# Altium schematic sheet ESP32-HWE-Dev-Power.SchDoc(ESP32-HWE-Dev-Power)
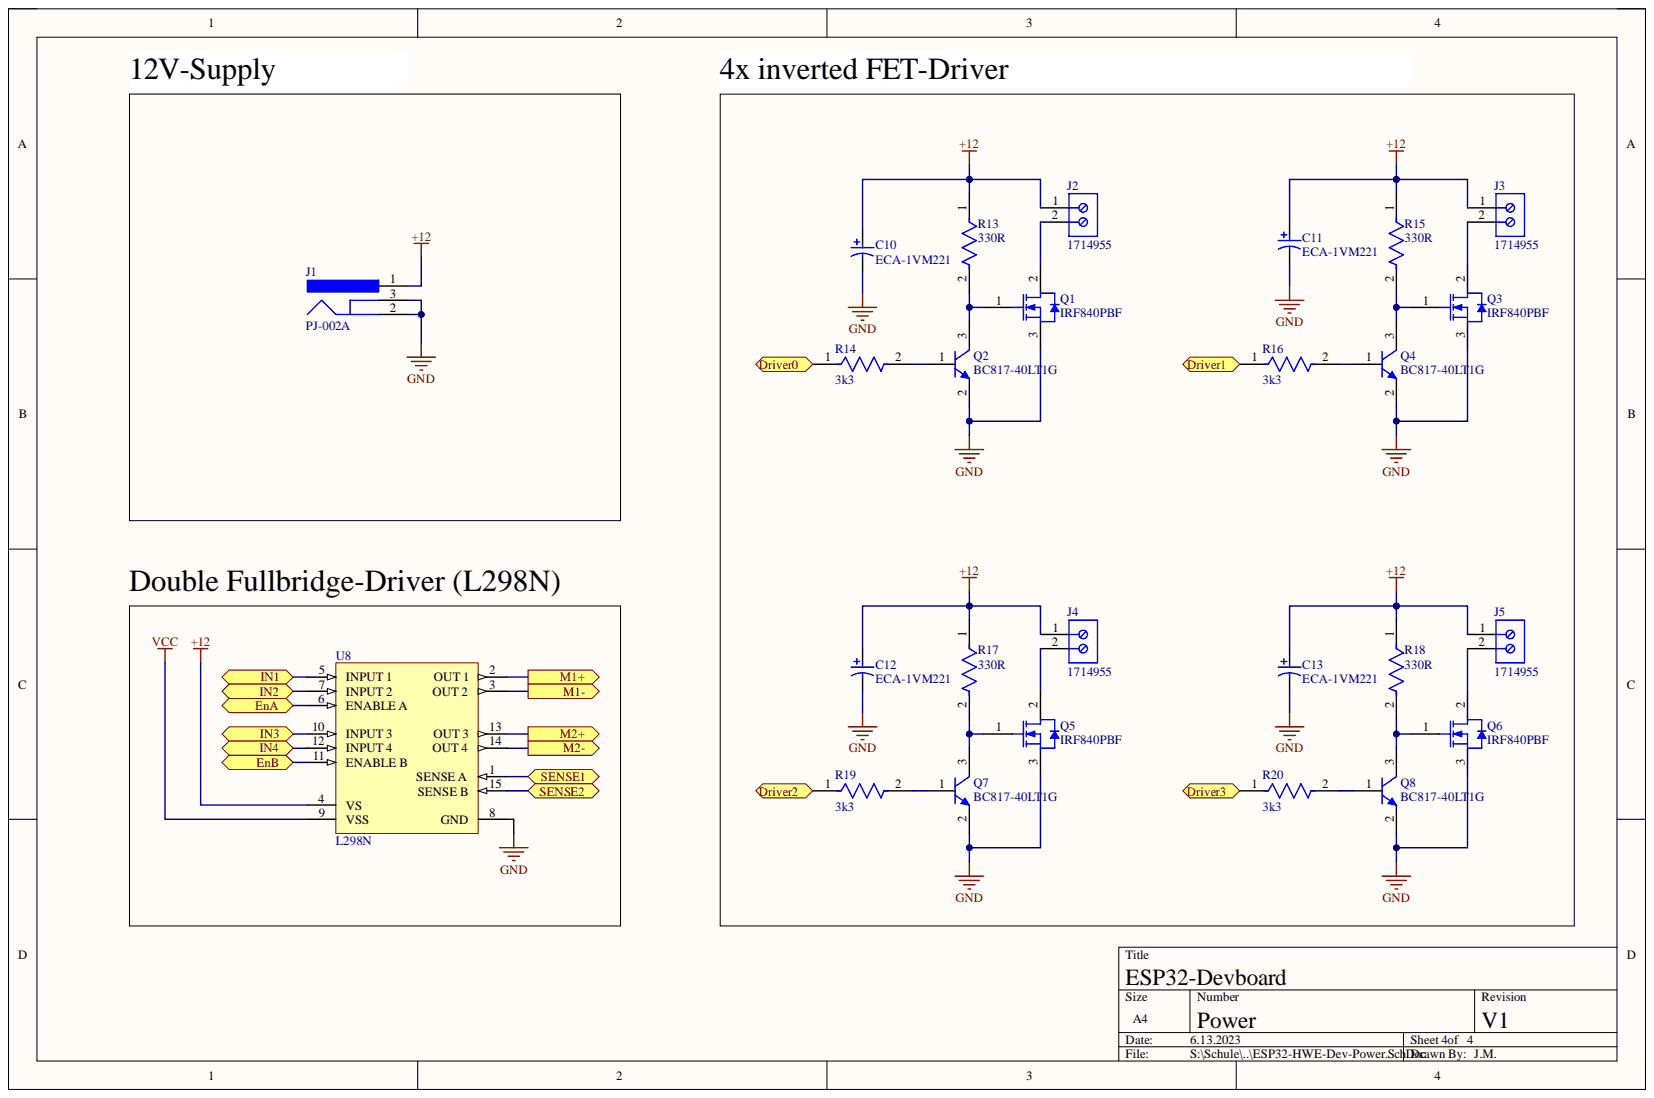
[diagram p0: ESP32-HWE-Dev-Power.SchDoc]

## Parts
C10  ECA-1VM221  [PNSC-ECA-1VM221-2]
C11  ECA-1VM221  [PNSC-ECA-1VM221-2]
C12  ECA-1VM221  [PNSC-ECA-1VM221-2]
C13  ECA-1VM221  [PNSC-ECA-1VM221-2]
J1  PJ-002A  [CUI-PJ-002A_V]
J2  1714955  [PHOE-1714955_V]
J3  1714955  [PHOE-1714955_V]
J4  1714955  [PHOE-1714955_V]
J5  1714955  [PHOE-1714955_V]
Q1  IRF840PBF  [VISH-TO-220AB-3]
Q2  BC817-40LT1G  [ONSC-SOT-23-3-318-08_V]
Q3  IRF840PBF  [VISH-TO-220AB-3]
Q4  BC817-40LT1G  [ONSC-SOT-23-3-318-08_V]
Q5  IRF840PBF  [VISH-TO-220AB-3]
Q6  IRF840PBF  [VISH-TO-220AB-3]
Q7  BC817-40LT1G  [ONSC-SOT-23-3-318-08_V]
Q8  BC817-40LT1G  [ONSC-SOT-23-3-318-08_V]
R13  330R  [FP-AC0805-MFG]
R14  3k3  [FP-AC0805-MFG]
R15  330R  [FP-AC0805-MFG]
R16  3k3  [FP-AC0805-MFG]
R17  330R  [FP-AC0805-MFG]
R18  330R  [FP-AC0805-MFG]
R19  3k3  [FP-AC0805-MFG]
R20  3k3  [FP-AC0805-MFG]
U8  L298N  [STM-FM-15]

## Ports
Driver0, Driver1, Driver2, Driver3, EnA, EnB, IN1, IN2, IN3, IN4, M1+, M1-, M2+, M2-, SENSE1, SENSE2

## Nets
+12: C10.1 C11.1 C12.1 C13.1 J1.1 J2.1 J3.1 J4.1 J5.1 R13.1 R15.1 R17.1 R18.1 U8.4(VS)
GND: C10.2 C11.2 C12.2 C13.2 J1.2 J1.3 Q1.3 Q2.2 Q3.3 Q4.2 Q5.3 Q6.3 Q7.2 Q8.2 U8.8(GND)
VCC: U8.9(VSS)
Driver0: R14.1 ; port Driver0
Driver1: R16.1 ; port Driver1
Driver2: R19.1 ; port Driver2
Driver3: R20.1 ; port Driver3
EnA: U8.6(ENABLE_A) ; port EnA
EnB: U8.11(ENABLE_B) ; port EnB
IN1: U8.5(INPUT_1) ; port IN1
IN2: U8.7(INPUT_2) ; port IN2
IN3: U8.10(INPUT_3) ; port IN3
IN4: U8.12(INPUT_4) ; port IN4
SENSE1: U8.1(SENSE_A) ; port SENSE1
SENSE2: U8.15(SENSE_B) ; port SENSE2
NetJ2_2: J2.2 Q1.2
NetJ3_2: J3.2 Q3.2
NetJ4_2: J4.2 Q5.2
NetJ5_2: J5.2 Q6.2
NetQ1_1: Q1.1 Q2.3 R13.2
NetQ2_1: Q2.1 R14.2
NetQ3_1: Q3.1 Q4.3 R15.2
NetQ4_1: Q4.1 R16.2
NetQ5_1: Q5.1 Q7.3 R17.2
NetQ6_1: Q6.1 Q8.3 R18.2
NetQ7_1: Q7.1 R19.2
NetQ8_1: Q8.1 R20.2
NetU8_2: U8.2(OUT_1)
NetU8_3: U8.3(OUT_2)
NetU8_13: U8.13(OUT_3)
NetU8_14: U8.14(OUT_4)

## Library data of the parts
C10-C13 = CMP-2000-05311-1: Aluminum Electrolytic Capacitor, 220 uF, +/- 20%, 35 V, -40 to 85 degC, 2-Pin THD, RoHS, Bulk; Manufacturer: Panasonic; Capacitance: 220µF; Lifetime@Temperature: 2000Hrs@85degC; Rated Voltage: 35V; Ripple Current: 350mA; Type: Radial; Case Size/Dimension: Ø8x11.5mm; Component Kind: Standard; Datasheet URL: https://datasheet.ciiva.com/26341/441851-da-01-en-elko-eca1vm221-m-r-220myf-35v-85c-26341906.pdf; Datasheet Version: Mar-06; Library Name: Altium Content Vault; Manufacturer URL: http://www.panasonic.com/; Operating Temp Range: -40to+85degC; Operating Temp-Max: 85degC; Package Description: 2-Pin Axial Lead, Dia 8 mm, Body Length 12.5 mm; Package Reference: ECA-1VM221; Series: M
J1 = CMP-2000-06895-2: Through Hole Right Angle DC Power Jack, 2.5 A, 2 mm Center Pin, 3 Position, -25 to 85 degC, RoHS, Bulk; Manufacturer: CUIInc.; Brand: CUI; Current Rating: 2.5A; Inside Contact Diameter: 2mm; Insulation Resistance: 50MOhms; Outside Contact Diameter: 6.5mm; Voltage Rating: 24V; Component Kind: Standard; Connector Type: DCPowerJack; Contact Gender: Male; Contact Material: Copper; Contact Plating: Nickel; Datasheet URL: http://www.cui.com/product/resource/pj-002a.pdf; Datasheet Version: Rev. 1.03, 04/2016; Factory Pack Quantity: 500; Flammability Rating: UL94V-0; Housing Material: PolybutyleneTerephthalate(PBT); Library Name: Altium Content Vault; Manufacturer URL: http://www.cui.com/; Mounting Angle: Right; Mounting Style: ThroughHole; Mounting Technology: ThroughHole; Operating Temperature Range: -25Cto+85C; Package Description: 3-Pin Through Hole Device, Body 14.4 x 9 mm, Height 11 mm; Package Reference: PJ-002A; Product: Jacks; Product Category: DCPowerConnectors; RoHS: True; Termination Style: ThroughHole
J2-J5 = CMP-2000-05797-1: PC Terminal Block, Pitch 6.35 mm, 1 x 2 Position, Height 21.5 mm, Tail Lenght 5.1 mm, RoHS, Bulk; Manufacturer: Phoenix Contact; Component Kind: Standard; Datasheet URL: http://media.digikey.com/pdf/Data%20Sheets/Phoenix%20Contact%20PDFs/1714955.pdf; Datasheet Version: 02/2010; Library Name: Altium Content Vault; Manufacturer URL: http://www.phoenixcontact.com/; Mounting Technology: ThroughHole; Package Description: PC Terminal Block, Pitch 6.35 mm, 1 x 2 Position, Height 21.5 mm, Tail Lenght 5.1 mm; Package Reference: 1714955
Q1 Q3 Q5 Q6 = CMP-2000-06467-1: Power MOSFET, 500 V, -55 to 150 degC, 3-Pin FM, RoHS, Tape and Reel; Manufacturer: Vishay; Channel Mode: Enhancement; Channel Type: N; Maximum Continuous Drain Current: 8A; Maximum Drain Source Resistance: 0.85Ω; Maximum Drain Source Voltage: 500V; Maximum Gate Source Voltage: ±20V; Maximum Power Dissipation: 125W; Minimum Gate Threshold Voltage: 2V; Number of Elements per Chip: 1; Typical Gate Charge @ Vgs: 63nC@10V; Typical Input Capacitance @ Vds: 1300pF@25V; Typical Turn-Off Delay Time: 49ns; Typical Turn-On Delay Time: 14ns; Category: PowerMOSFET; Component Kind: Standard; Datasheet URL: https://datasheet.ciiva.com/26791/irf840pbf-26791500.pdf; Datasheet Version: Rev. C, 03/2011; Dimensions: 10.41x4.7x9.01mm; Height: 9.01mm; Length: 10.41mm; Library Name: Altium Content Vault; Manufacturer URL: http://www.vishay.com/; Maximum Operating Temperature: +150°C; Minimum Operating Temperature: -55°C; Mounting Technology: ThroughHole; Mounting Type: ThroughHole; Package Description: 3-Pin Flange Mount, Body 10.275 x 4.45 mm, Pitch 2.54 mm; Package Reference: TO-220AB-3; Package Type: TO-220AB; Package URL: http://www.vishay.com/docs/71195/to220ab.pdf; Pin Count: 3; Width: 4.7mm
Q2 Q4 Q7 Q8 = CMP-1048-00019-1: General Purpose Transistor, NPN Silicon, 3-Pin SOT-23, Pb-Free, Tape and Reel; Manufacturer: On Semiconductor; fT Min (MHz): 100; IC Continuous (A): 0.5; Component Kind: Standard; ComponentLink1Description: Manufacturer URL; ComponentLink1URL: http://www.onsemi.com/; ComponentLink2Description: Datasheet; ComponentLink2URL: http://www.onsemi.com/pub_link/Collateral/BC817-16LT1-D.PDF; ComponentLink3Description: Package Specification; ComponentLink3URL: http://www.onsemi.cn/pub_link/Collateral/318-08.PDF; DatasheetVersion: Rev. 12; Library Name: Altium Content Vault; Mounting Technology: Surface Mount; PackageDescription: 3-Pin Small Outline Transistor, Body 2.92 x 1.3 mm, Pitch 0.95 mm; PackageReference: SOT-23-3-318-08; PackageVersion: Rev. AP, 11/2009; Packing: Tape and Reel; PartNumber: BC817-40LT1G; Polarity: NPN; RoHS: TRUE
R13-R20 = CMP-2001-04476-2: RES SMD 4.7K OHM 5% 1/8W 0805; Manufacturer: Yageo; Manufacturer Part Number: AC0805JR-074K7L; Automotive Grade: Grade 0; Resistance: 4.7KΩ; Tolerance: 0.05; Value: 4.7KΩ; Voltage Rating: 150V; Automotive: Yes; Category: Res; Component Kind: Standard; ComponentLink2Description: Datasheet; ComponentLink2URL: http://www.yageo.com/documents/recent/PYu-AC_51_RoHS_L_6.pdf; Composition: Thick Film; Device Class L1: Passive Components; Device Class L2: Resistors; Device Class L3: Chip SMD Resistors; Digikey Description: RES SMD 4.7K OHM 5% 1/8W 0805; Footprint Url: http://www.yageo.com/exep/pages/download/literatures/PYu-R_Mount_10.pdf; Height: 0.6mm; Ipc Land Pattern Name: RESC200125X50; Lead Free: Yes; Library Name: Altium Content Vault; Mouser Description: Thick Film Resistors - SMD 1/8W 4.7K ohm 5% AEC-Q200; Octopart: https://octopart.com/ac0805jr-074k7l-yageo-26638632; Package: AC0805; Power Rating: 1/8W; RoHS: TRUE; Series: AC; Temperature: -55°C to +155°C; Temperature Range High: +155°C; Temperature Range Low: -55°C
U8 = CMP-2000-06526-1: Dual Full-Bridge Driver, 4.8 to 46 V, -40 to 150 degC, 15-Pin FM (MW 15L), RoHS, Tube; Manufacturer: STMicroelectronics; PartNumber: L298; Driver Case Style: Multiwatt; Motor Type: FullBridge; No. of Outputs: 4Outputs; No. of Pins: 15Pins; Output Current: 2A; Output Voltage: 46V; Supply Voltage Max: 7V; Supply Voltage Min: 4.5V; SVHC: NoSVHC(17-Dec-2015); Automotive Qualification Standard: -; Component Kind: Standard; Datasheet URL: https://datasheet.ciiva.com/15109/getdatasheetpartid-50682-15109332.pdf; Datasheet Version: 01/2000; Library Name: Altium Content Vault; Manufacturer URL: http://www.st.com/; Mounting Technology: ThroughHole; MSL: -; Operating Temperature Max: 130°C; Operating Temperature Min: -25°C; Package Description: 15-Pin Flange Mount, Body 5 x 19.6 mm, Pitch 1.27 mm; Package Reference: FM-15; Packaging: Each; Product Range: -
